# Altium schematic sheet RS485.SchDoc
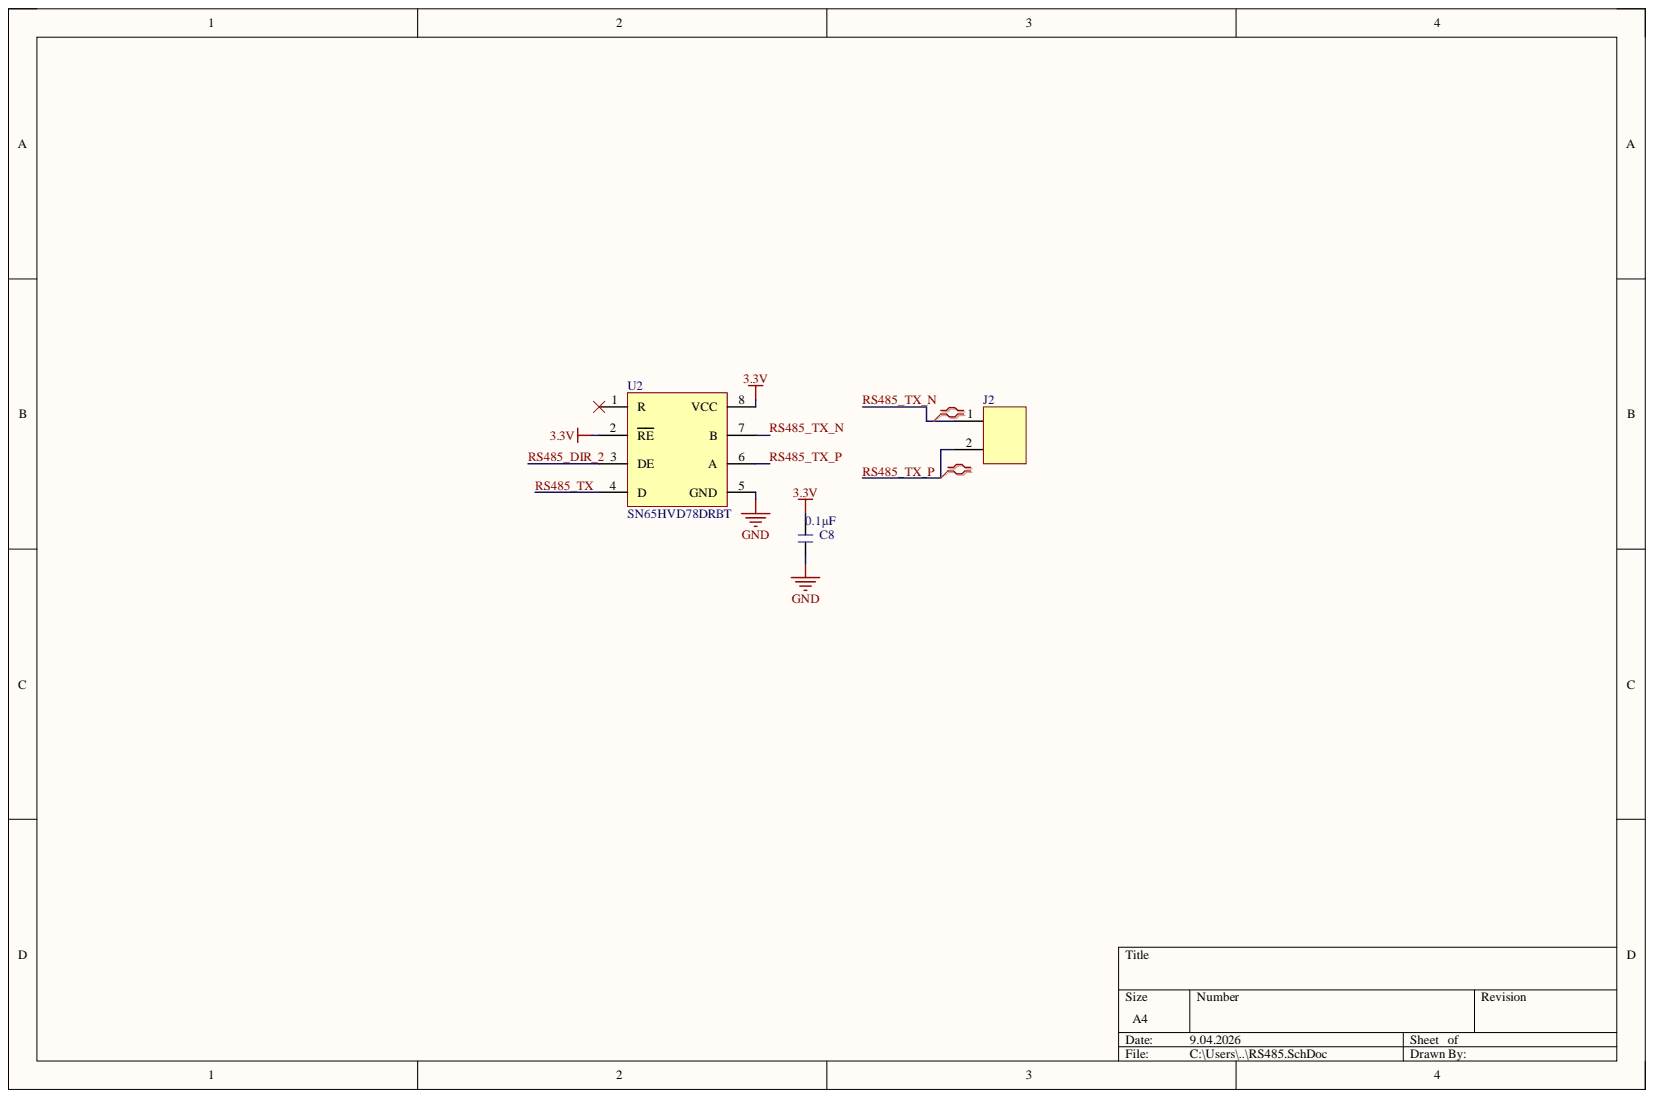
[diagram p0: RS485.SchDoc]

## Parts
C8  value 0.1µF  [402 capacitor - duplicate]  (hidden comment: 0402 capacitor)
J2  2 POS  [0901362102]  (value not drawn)
U2  SN65HVD78DRBT

## Nets
3.3V: C8.2 U2.2(RE) U2.8(VCC)
GND: C8.1 U2.5(GND)
RS485_DIR_2: U2.3(DE)
RS485_TX: U2.4(D)
RS485_TX_N: J2.1 U2.7(B)
RS485_TX_P: J2.2 U2.6(A)

Not connected: U2 1(R)

## Library data of the parts
C8 = CON SMD 402: MPN: CL05A104KA5NNNC; Component Kind: Standard; Description: CON SMD 402; Library Name: Schlib1.SchLib; Package: 0402
J2 = 0901362102: CONN HEADER R/A 2POS 2.54MM; Component Kind: Standard; Library Name: Schlib1.SchLib; MPN: 0901362102
U2 = SN65HVD78DRBT: IC TRANSCEIVER HALF 1/1 8SON (-7V +12V - 54 Ω); Component Kind: Standard; Library Name: Schlib1.SchLib; MPN: SN65HVD78DRBT
